# Altium schematic sheet SmartCar-Shield-V1.1.SchDoc
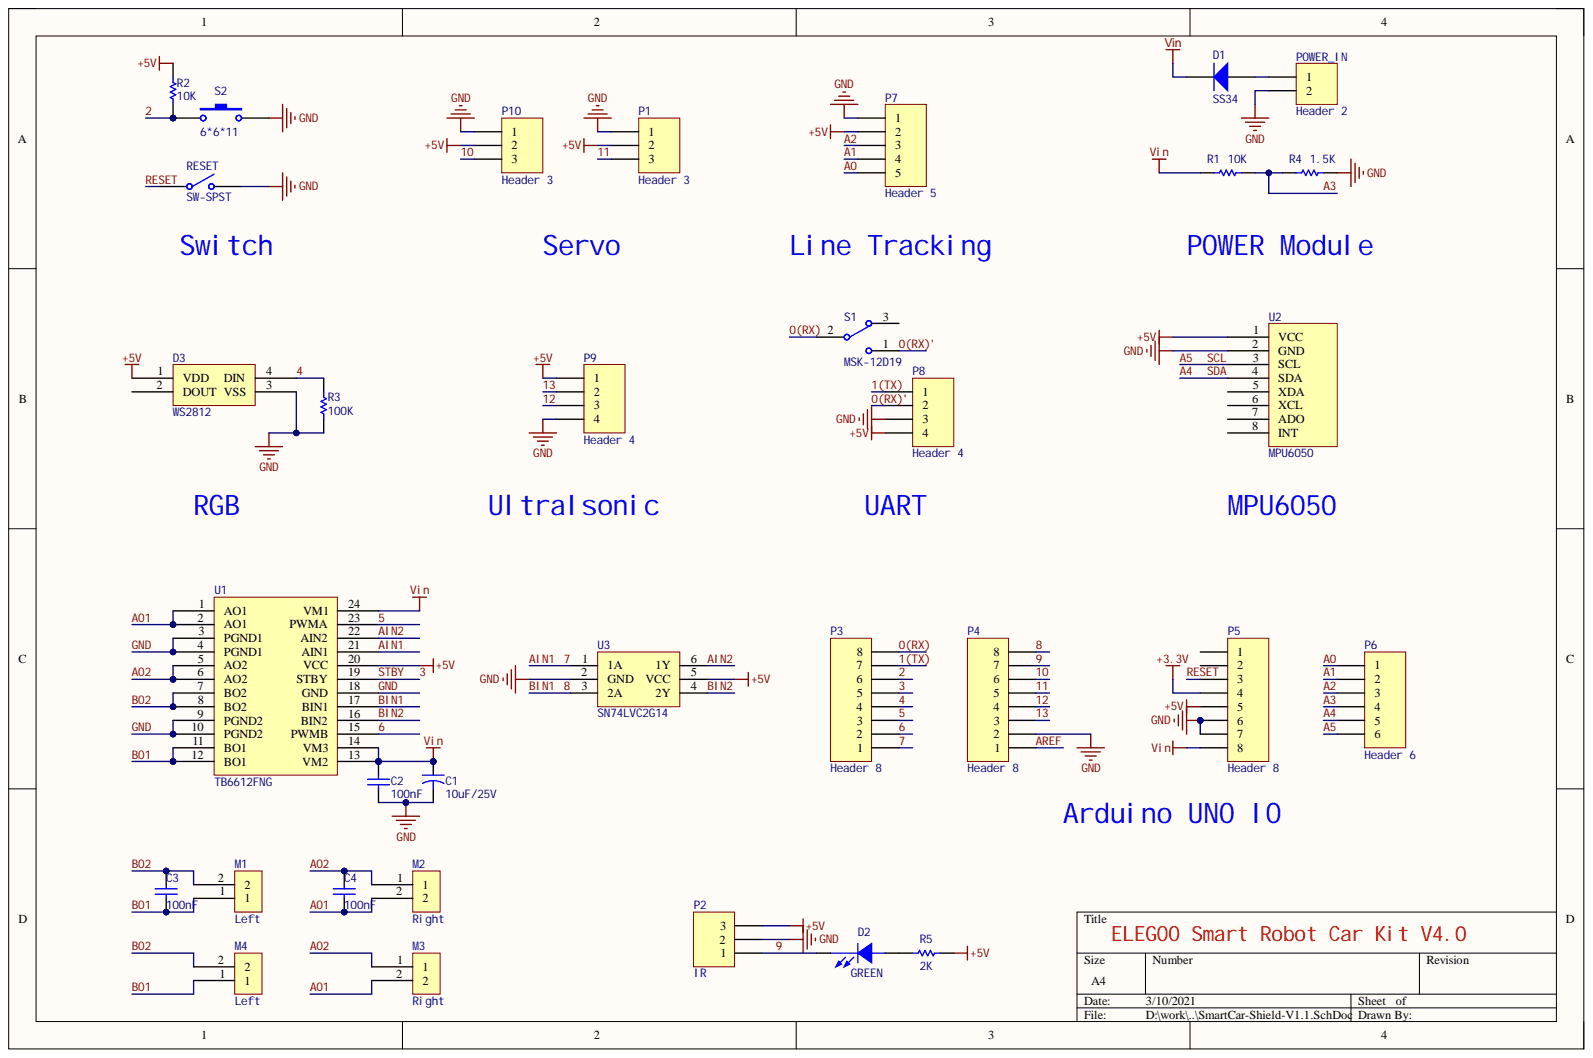
[diagram p0: SmartCar-Shield-V1.1.SchDoc]

## Parts
C1  10uF/25V  [c1206]
C2  100nF  [C0603]
C3  100nF  [C0603]
C4  100nF  [C0603]
D1  SS34  [SMA]
D2  GREEN  [led0603]
D3  WS2812  [D5050-WS2812]
M1  Left  [XH2.54-2A]
M2  Right  [XH2.54-2A]
M3  Right  [XH2.54-2A]
M4  Left  [XH2.54-2A]
P1  Header 3  [HDR1X3]
P2  IR  [INF]
P3  Header 8  [HDR1X8]
P4  Header 8  [HDR1X8]
P5  Header 8  [HDR1X8]
P6  Header 6  [HDR1X6]
P7  Header 5  [XH2.54-5A]
P8  Header 4  [XH2.54-4A]
P9  Header 4  [XH2.54-4A]
P10  Header 3  [HDR1X3]
POWER_IN  Header 2  [XH2.54-2A]
R1  10K  [R0603]
R2  10K  [R0603]
R3  100K  [R0603]
R4  1.5K  [R0603]
R5  2K  [R0603]
RESET  SW-SPST  [Button6X3-T]
S1  MSK-12D19  [MSK-12D19]
S2  6*6*11  [Button6*6*7]
U1  TB6612FNG  [SSOP-24]
U2  MPU6050  [MPU6050]
U3  SN74LVC2G14  [sot-23-6]

## Nets
+3.3V: P5.4
Vin: C1.1 C2.1 D1.2 P5.8 R1.1 U1.13(VM2) U1.14(VM3) U1.24(VM1)
0(RX): P3.8 S1.2
0(RX)': P8.2 S1.1
1(TX): P3.7 P8.1
2: P3.6 R2.2 S2.1
3: P3.5 U1.19(STBY)
4: D3.4(DIN) P3.4 R3.2
6: P3.2 U1.15(PWMB)
7: P3.1 U1.21(AIN1) U3.1(1A)
8: P4.8 U1.17(BIN1) U3.3(2A)
9: D2.2 P2.1 P4.7
10: P4.6 P10.3
11: P1.3 P4.5
12: P4.4 P9.3
13: P4.3 P9.2
+5V: D3.1(VDD) P1.2 P2.3 P3.3 P5.5 P7.2 P8.4 P9.1 P10.2 R2.1 R5.2 U1.20(VCC) U1.23(PWMA) U2.1(VCC) U3.5(VCC)  [also labelled 5]
A0: P6.1 P7.5
A1: P6.2 P7.4
A2: P6.3 P7.3
A3: P6.4 R1.2 R4.1
A4: P6.5 U2.4(SDA)
A5: P6.6 U2.3(SCL)
AIN2: U1.22(AIN2) U3.6(1Y)
AO1: C4.2 M2.2 M3.2 U1.1(AO1) U1.2(AO1)
AO2: C4.1 M2.1 M3.1 U1.5(AO2) U1.6(AO2)
AREF: P4.1
BIN2: U1.16(BIN2) U3.4(2Y)
BO1: C3.2 M1.1 M4.1 U1.11(BO1) U1.12(BO1)
BO2: C3.1 M1.2 M4.2 U1.7(BO2) U1.8(BO2)
GND: C1.2 C2.2 D3.3(VSS) P1.1 P2.2 P4.2 P5.6 P5.7 P7.1 P8.3 P9.4 P10.1 POWER_IN.2 R3.1 R4.2 RESET.2 S2.2 U1.3(PGND1) U1.4(PGND1) U1.9(PGND2) U1.10(PGND2) U1.18(GND) U2.2(GND) U3.2(GND)
RESET: P5.3 RESET.1
NetD1_1: D1.1 POWER_IN.1
NetD2_1: D2.1 R5.1

Not connected: D3 2(DOUT); P5 1 2; S1 3; U2 5(XDA) 6(XCL) 7(ADO) 8(INT)

## Library data of the parts
C1 = Cap2: Capacitor; Value: 47uF; Component Kind: Standard; Library Name: Miscellaneous Devices.IntLib; PackageReference: CAPR5-4X5; Published: 23-Sep-2002; Signal Integrity: Cap; Simulation: CAP; Publisher: Altium Limited
C2-C4 = Cap: Capacitor; Value: 22pF; Component Kind: Standard; LatestRevisionDate: 17-Jul-2002; LatestRevisionNote: Re-released for DXP Platform.; Library Name: Miscellaneous Devices.IntLib; PackageReference: RAD-0.3; Published: 8-Jun-2000; Signal Integrity: Cap; Simulation: CAP; Publisher: Altium Limited
D1 = Diode: Default Diode; Code_JEDEC: DO-214-AB; Component Kind: Standard; LatestRevisionDate: 17-Jul-2002; LatestRevisionNote: Re-released for DXP Platform.; Library Name: Miscellaneous Devices.IntLib; PackageDescription: DO-214-AB/SMC; 2 C-Bend Leads; Body 7.9 x 5.9 mm, inc. leads (LxW); PackageReference: SMC; PackageVersion: Sep-1996; Published: 8-Jun-2000; Signal Integrity: Diode; Simulation: DIODE; Publisher: Altium Limited
D2 = LED_1: Component Kind: Standard
D3 = D5050: Component Kind: Standard; Library Name: BalanceCarRobot-PCB-V01.SCHLIB
M1-M4 = 2PIN: Component Kind: Standard; Library Name: my.SchLib
P1 P2 P10 = Header 3: Header, 3-Pin; Component Kind: Standard; LatestRevisionDate: 17-Jul-2002; LatestRevisionNote: Re-released for DXP Platform.; Library Name: Miscellaneous Connectors.IntLib; Signal Integrity: Connector; Publisher: Altium Limited
P3-P5 = Header 8: Header, 8-Pin; Component Kind: Standard; LatestRevisionDate: 17-Jul-2002; LatestRevisionNote: Re-released for DXP Platform.; Library Name: Miscellaneous Connectors.IntLib; Signal Integrity: Connector; Publisher: Altium Limited
P6 = Header 6: Header, 6-Pin; Component Kind: Standard; LatestRevisionDate: 17-Jul-2002; LatestRevisionNote: Re-released for DXP Platform.; Library Name: Miscellaneous Connectors.IntLib; Signal Integrity: Connector; Publisher: Altium Limited
P7 = Header 5: Header, 5-Pin; Component Kind: Standard; LatestRevisionDate: 17-Jul-2002; LatestRevisionNote: Re-released for DXP Platform.; Library Name: Miscellaneous Connectors.IntLib; Signal Integrity: Connector; Publisher: Altium Limited
P8 P9 = Header 4: Header, 4-Pin; Component Kind: Standard; LatestRevisionDate: 17-Jul-2002; LatestRevisionNote: Re-released for DXP Platform.; Library Name: Miscellaneous Connectors.IntLib; Signal Integrity: Connector; Publisher: Altium Limited
POWER_IN = Header 2: Header, 2-Pin; Component Kind: Standard; LatestRevisionDate: 17-Jul-2002; LatestRevisionNote: Re-released for DXP Platform.; Library Name: Miscellaneous Connectors.IntLib; Signal Integrity: Connector; Publisher: Altium Limited
R1 R4 = Res1: Resistor; Value: 1K; Component Kind: Standard; LatestRevisionDate: 17-Jul-2002; LatestRevisionNote: Re-released for DXP Platform.; Library Name: Miscellaneous Devices.IntLib; PackageDescription: Axial Device, Thru-Hole; 2 Leads; 0.3 in Pin Spacing; PackageReference: AXIAL-0.3; Published: 8-Jun-2000; Signal Integrity: Res; Simulation: RESISTOR; Publisher: Altium Limited
R2 R5 = Res1: Resistor; Value: 150R; Component Kind: Standard; LatestRevisionDate: 17-Jul-2002; LatestRevisionNote: Re-released for DXP Platform.; Library Name: Miscellaneous Devices.IntLib; PackageDescription: Axial Device, Thru-Hole; 2 Leads; 0.3 in Pin Spacing; PackageReference: AXIAL-0.3; Published: 8-Jun-2000; Signal Integrity: Res; Simulation: RESISTOR; Publisher: Altium Limited
R3 = Res1: Resistor; Value: 1k; Component Kind: Standard; LatestRevisionDate: 17-Jul-2002; LatestRevisionNote: Re-released for DXP Platform.; Library Name: Miscellaneous Devices.IntLib; PackageDescription: Axial Device, Thru-Hole; 2 Leads; 0.3 in Pin Spacing; PackageReference: AXIAL-0.3; Published: 8-Jun-2000; Signal Integrity: Res; Simulation: RESISTOR; Publisher: Altium Limited
RESET = SW-SPST: Single-Pole, Single-Throw Switch; Component Kind: Standard; LatestRevisionDate: 17-Jul-2002; LatestRevisionNote: Re-released for DXP Platform.; Library Name: Miscellaneous Devices.IntLib; PackageDescription: Switch; 2 Leads; PackageReference: SPST-2; Published: 24-Mar-1999; Publisher: Altium Limited
S1 = SW-SPDT: SPDT Subminiature Toggle Switch, Right Angle Mounting, Vertical Actuation; Component Kind: Standard; ComponentLink1Description: Manufacturer Link; ComponentLink1URL: http://www.apem.com; DatasheetVersion: 05-Dec-2000; LatestRevisionDate: 17-Dec-2003; LatestRevisionNote: Schematic revised, PCB Footprint replaced.; Library Name: TankCar-Shield-V04.SCHLIB; PackageDescription: Switch; 4 Leads; PackageReference: TL36WW15050; Published: 24-Mar-1999; Publisher: Altium Limited
S2 = SW-PB: Switch; Component Kind: Standard; LatestRevisionDate: 17-Jul-2002; LatestRevisionNote: Re-released for DXP Platform.; Library Name: Miscellaneous Devices.IntLib; PackageDescription: Switch; 2 Leads; PackageReference: SPST-2; Published: 24-Mar-1999; Publisher: Altium Limited
U1 = TB6612FNG: Component Kind: Standard; Library Name: TB6612FNG-PCB-V01.SCHLIB
U2 = MPU6050: Component Kind: Standard; Library Name: BalanceCarRobot-PCB-V01.SCHLIB
U3 = SN74LVC2G14: Component Kind: Standard; Description: SN74LVC2G14; Library Name: BalanceCarRobot-PCB-V04.SCHLIB
